# Altium schematic sheet 4_NRF9160.SchDoc(4_NRF9160)
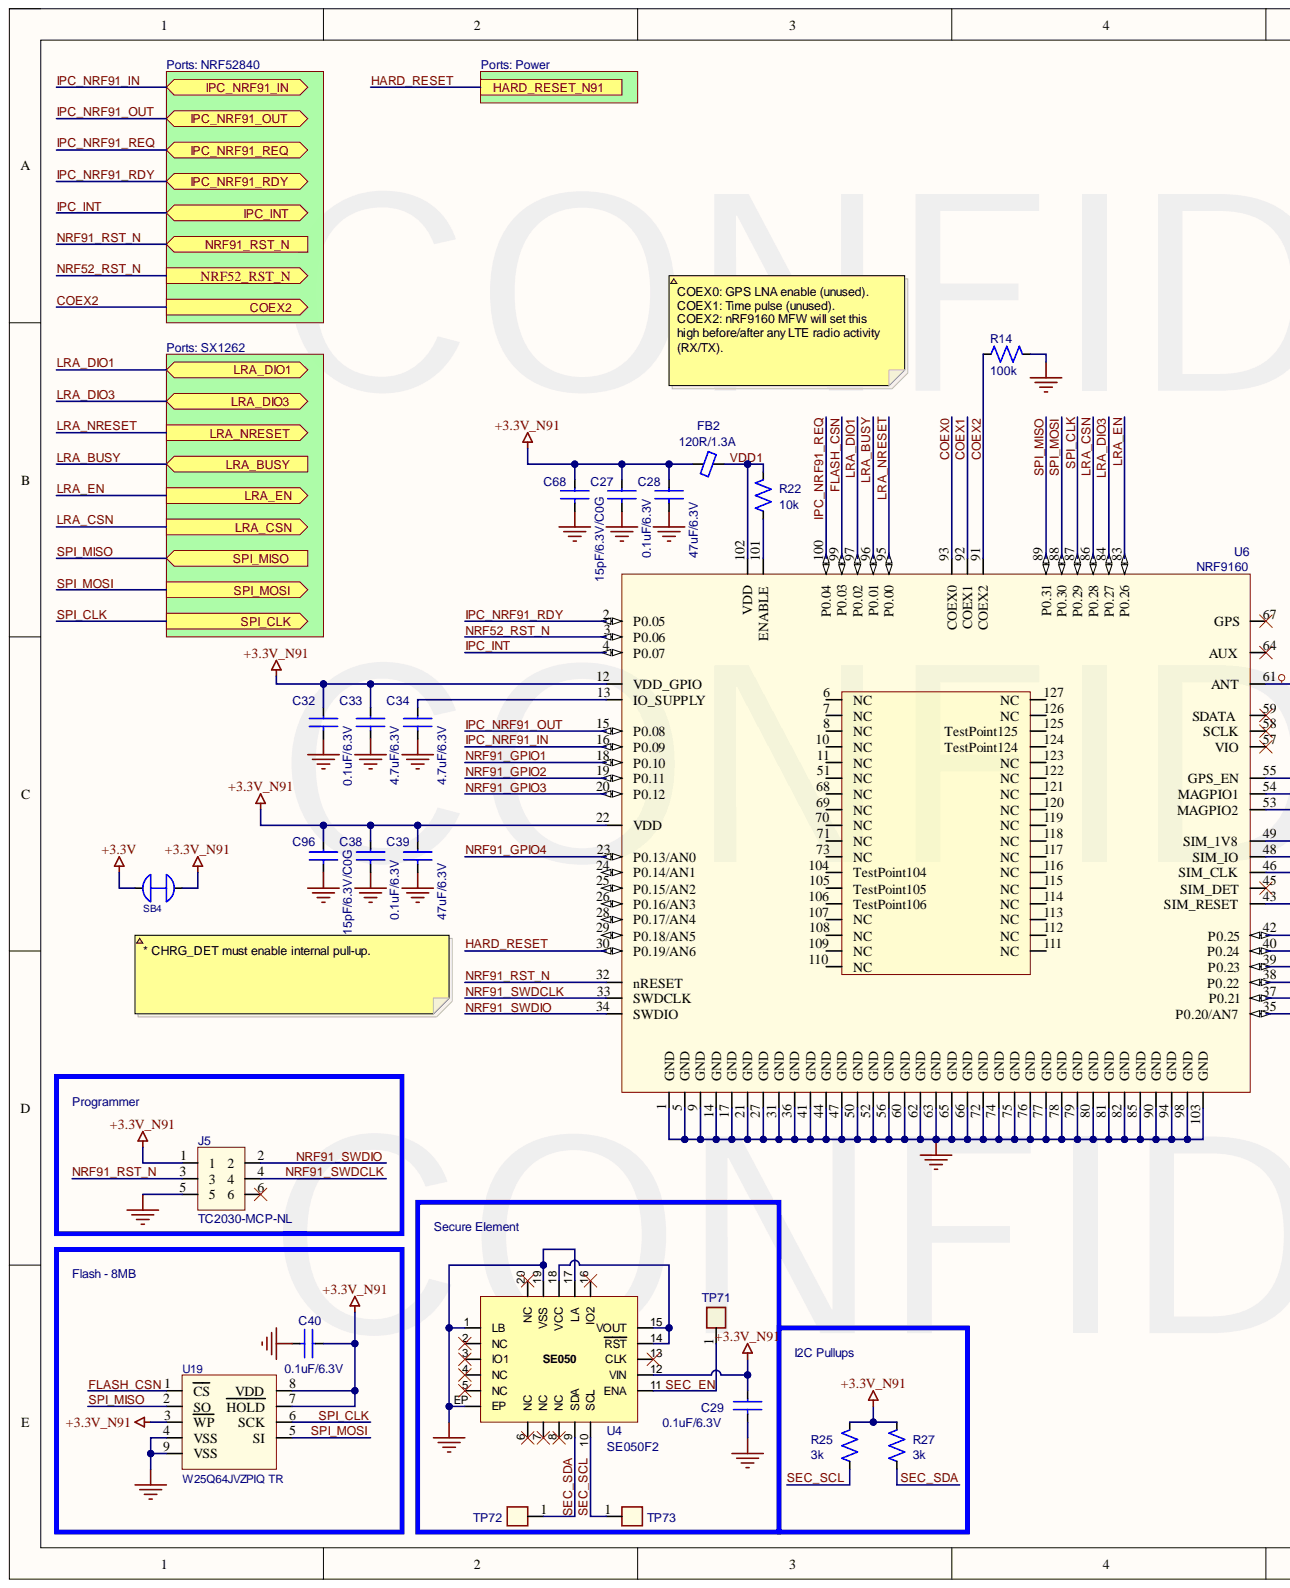
[diagram p0: 4_NRF9160.SchDoc, left]
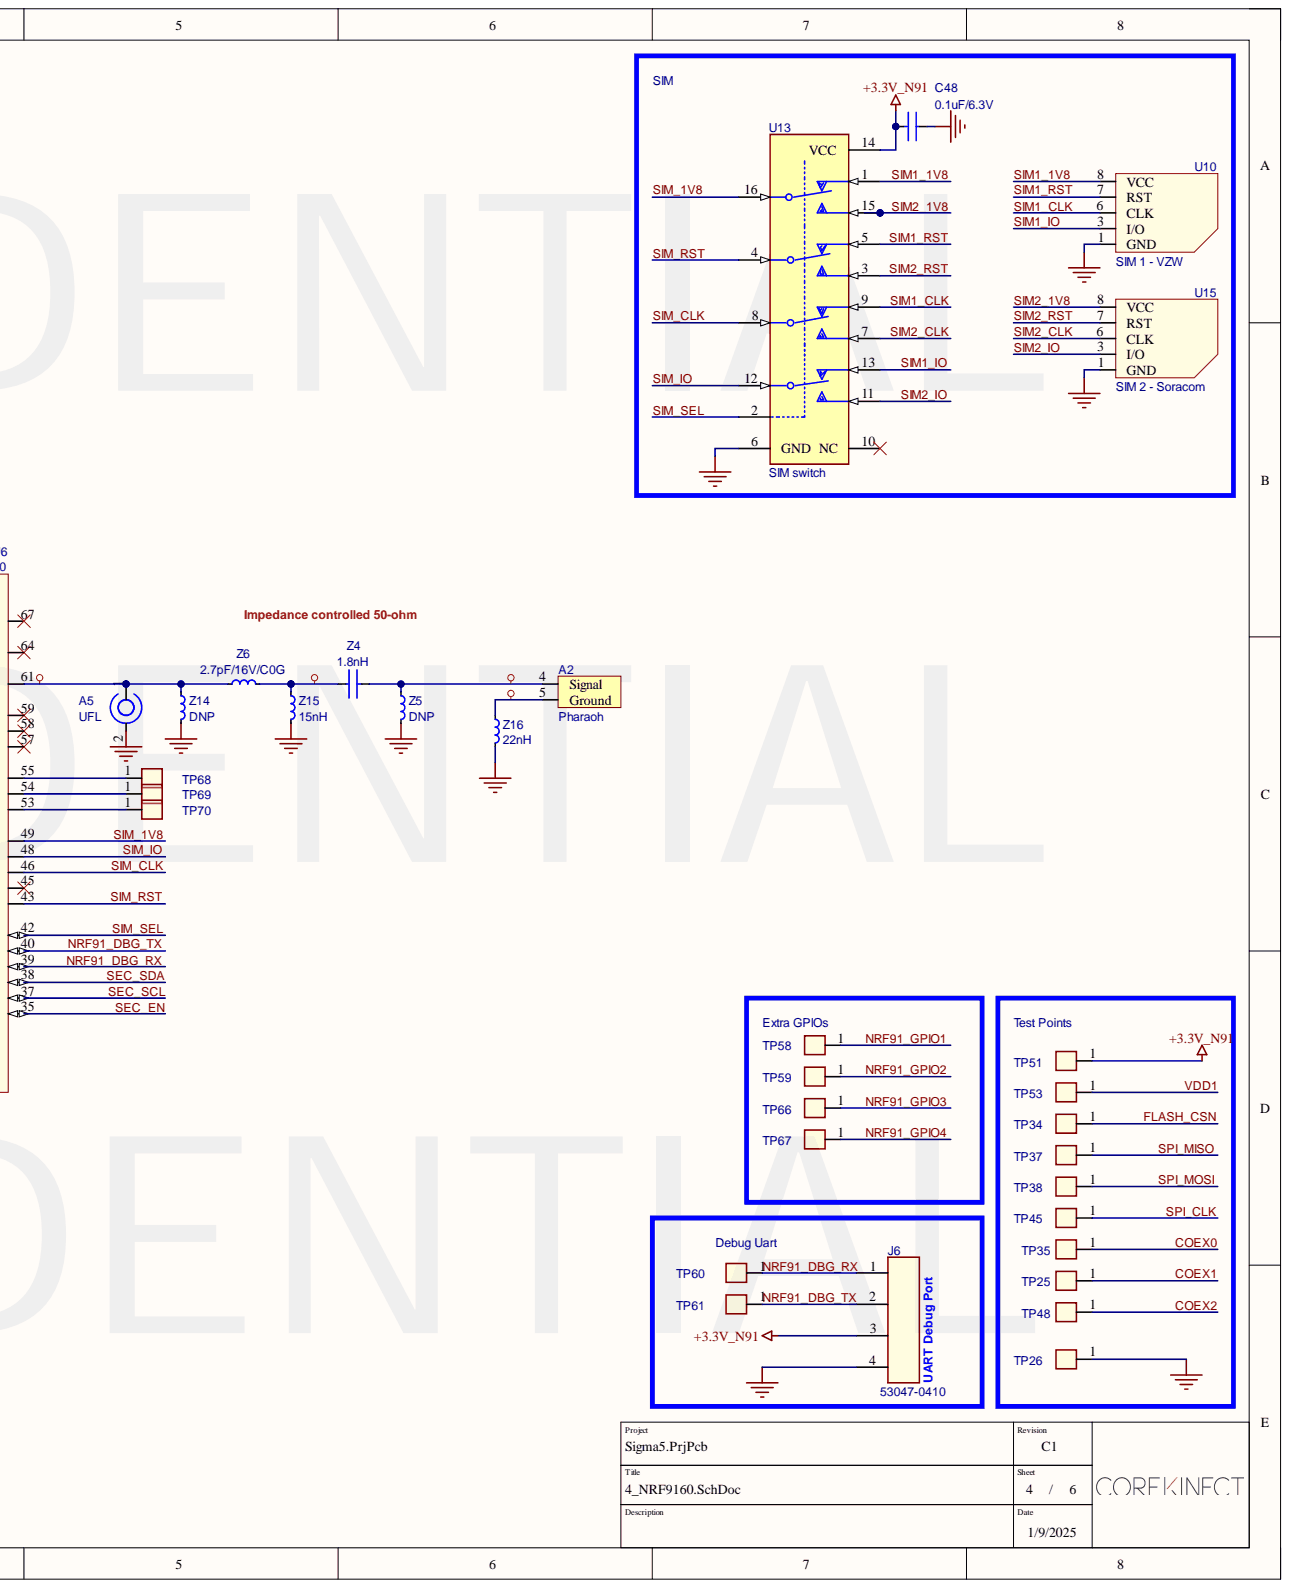
[diagram p1: 4_NRF9160.SchDoc, right]

## Parts
A2  Pharaoh  [Pharaoh_Ant]
A5  UFL  [U.FL-INLINE]
C27  0.1uF/6.3V  [CAP_0402]
C28  47uF/6.3V  [SMT_0805]
C29  0.1uF/6.3V  [CAP_0402]
C32  0.1uF/6.3V  [CAP_0402]
C33  4.7uF/6.3V  [CAP_0603]
C34  4.7uF/6.3V  [CAP_0603]
C38  0.1uF/6.3V  [CAP_0402]
C39  47uF/6.3V  [SMT_0805]
C40  0.1uF/6.3V  [CAP_0402]
C48  0.1uF/6.3V  [CAP_0402]
C68  15pF/6.3V/C0G  [CAP_0402]
C96  15pF/6.3V/C0G  [CAP_0402]
FB2  120R/1.3A  [CAP_0402]
J5  TC2030-MCP-NL  [TC2030-MCP-NL]
J6  53047-0410  [0530470410_Molex]
R14  100k  [RES_0402]
R22  10k  [RES_0402]
R25  3k  [RES_0402]
R27  3k  [RES_0402]
SB4  SOLDER BRIDGE CLOSED  [RES_0402]  (value not drawn)
TP25  TP  [SMT_TP35_SM]
TP26  TP  [SMT_TP35_SM]
TP34  TP  [SMT_TP35_SM]
TP35  TP  [SMT_TP35_SM]
TP37  TP  [SMT_TP35_SM]
TP38  TP  [SMT_TP35_SM]
TP45  TP  [SMT_TP35_SM]
TP48  TP  [SMT_TP35_SM]
TP51  TP  [SMT_TP35_SM]
TP53  TP  [SMT_TP35_SM]
TP58  TP  [SMT_TP35_SM]
TP59  TP  [SMT_TP35_SM]
TP60  TP  [SMT_TP35_SM]
TP61  TP  [SMT_TP35_SM]
TP66  TP  [SMT_TP35_SM]
TP67  TP  [SMT_TP35_SM]
TP68  TP  [SMT_TP35_SM]
TP69  TP  [SMT_TP35_SM]
TP70  TP  [SMT_TP35_SM]
TP71  TP  [SMT_TP35_SM]
TP72  TP  [SMT_TP35_SM]
TP73  TP  [SMT_TP35_SM]
U4  SE050F2  [NXP_SE050]
U6  NRF9160  [nRF9160_DEV2_MODULE]
U10  SIM 1 - VZW  [SIM-ST-MFF2]
U13  SIM switch  [FP-523BF-MFG]
U15  SIM 2 - Soracom  Manufacturer 1: Soracom  [SIM-ST-MFF2]
U19  W25Q64JVZPIQ TR  [WSON8-6x5mm]
Z4  1.8nH  [CAP_0402]
Z5  DNP  [CAP_0402]
Z6  2.7pF/16V/C0G  [CAP_0402]
Z14  DNP  [CAP_0402]
Z15  15nH  [CAP_0402]
Z16  22nH  [CAP_0402]

## Ports
COEX2, HARD_RESET_N91, IPC_INT, IPC_NRF91_IN, IPC_NRF91_OUT, IPC_NRF91_RDY, IPC_NRF91_REQ, LRA_BUSY, LRA_CSN, LRA_DIO1, LRA_DIO3, LRA_EN, LRA_NRESET, NRF52_RST_N, NRF91_RST_N, SPI_CLK, SPI_MISO, SPI_MOSI

## Nets
+3.3V: SB4.1
+3.3V_N91: C27.1 C28.1 C29.1 C32.1 C33.1 C38.1 C39.1 C40.1 C48.2 C68.1 C96.1 FB2.1 J5.1 J6.3 R25.2 R27.2 SB4.2 TP51.1 U4.12(VIN) U6.12(VDD_GPIO) U6.22(VDD) U13.14(VCC) U19.3(WP) U19.7(HOLD) U19.8(VDD)
GND: A5.2 C27.2 C28.2 C29.2 C32.2 C33.2 C34.2 C38.2 C39.2 C40.2 C48.1 C68.2 C96.2 J5.5 J6.4 R14.2 TP26.1 U4.1(LB) U4.17(LA) U4.19(VSS) U4.EP U6.1(GND) U6.5(GND) U6.9(GND) U6.14(GND) U6.17(GND) U6.21(GND) U6.27(GND) U6.31(GND) U6.36(GND) U6.41(GND) U6.44(GND) U6.47(GND) U6.50(GND) U6.52(GND) U6.56(GND) U6.60(GND) U6.62(GND) U6.63(GND) U6.65(GND) U6.66(GND) U6.72(GND) U6.74(GND) U6.75(GND) U6.76(GND) U6.77(GND) U6.78(GND) U6.79(GND) U6.80(GND) U6.81(GND) U6.82(GND) U6.85(GND) U6.90(GND) U6.94(GND) U6.98(GND) U6.103(GND) U10.1(GND) U13.6(GND) U15.1(GND) U19.4(VSS) U19.9(VSS) Z5.2 Z14.2 Z15.2 Z16.2
COEX0: TP35.1 U6.93(COEX0)
COEX1: TP25.1 U6.92(COEX1)
COEX2: R14.1 TP48.1 U6.91(COEX2) ; port COEX2
FLASH_CSN: TP34.1 U6.99(P0.03) U19.1(CS)
HARD_RESET: U6.30(P0.19/AN6) ; port HARD_RESET_N91
IPC_INT: U6.4(P0.07) ; port IPC_INT
IPC_NRF91_IN: U6.16(P0.09) ; port IPC_NRF91_IN
IPC_NRF91_OUT: U6.15(P0.08) ; port IPC_NRF91_OUT
IPC_NRF91_RDY: U6.2(P0.05) ; port IPC_NRF91_RDY
IPC_NRF91_REQ: U6.100(P0.04) ; port IPC_NRF91_REQ
LRA_BUSY: U6.96(P0.01) ; port LRA_BUSY
LRA_CSN: U6.86(P0.28) ; port LRA_CSN
LRA_DIO1: U6.97(P0.02) ; port LRA_DIO1
LRA_DIO3: U6.84(P0.27) ; port LRA_DIO3
LRA_EN: U6.83(P0.26) ; port LRA_EN
LRA_NRESET: U6.95(P0.00) ; port LRA_NRESET
NRF52_RST_N: U6.3(P0.06) ; port NRF52_RST_N
NRF91_DBG_RX: J6.1 TP60.1 U6.39(P0.23)
NRF91_DBG_TX: J6.2 TP61.1 U6.40(P0.24)
NRF91_GPIO1: TP58.1 U6.18(P0.10)
NRF91_GPIO2: TP59.1 U6.19(P0.11)
NRF91_GPIO3: TP66.1 U6.20(P0.12)
NRF91_GPIO4: TP67.1 U6.23(P0.13/AN0)
NRF91_RST_N: J5.3 U6.32(nRESET) ; port NRF91_RST_N
NRF91_SWDCLK: J5.4 U6.33(SWDCLK)
NRF91_SWDIO: J5.2 U6.34(SWDIO)
SEC_EN: TP71.1 U4.11(ENA) U6.35(P0.20/AN7)
SEC_SCL: R25.1 TP73.1 U4.10(SCL) U6.37(P0.21)
SEC_SDA: R27.1 TP72.1 U4.9(SDA) U6.38(P0.22)
SIM1_1V8: U10.8(VCC) U13.1
SIM1_CLK: U10.6(CLK) U13.9
SIM1_IO: U10.3(I/O) U13.13
SIM1_RST: U10.7(RST) U13.5
SIM2_1V8: U13.15 U15.8(VCC)
SIM2_CLK: U13.7 U15.6(CLK)
SIM2_IO: U13.11 U15.3(I/O)
SIM2_RST: U13.3 U15.7(RST)
SIM_1V8: U6.49(SIM_1V8) U13.16
SIM_CLK: U6.46(SIM_CLK) U13.8
SIM_IO: U6.48(SIM_IO) U13.12
SIM_RST: U6.43(SIM_RESET) U13.4
SIM_SEL: U6.42(P0.25) U13.2
SPI_CLK: TP45.1 U6.87(P0.29) U19.6(SCK) ; port SPI_CLK
SPI_MISO: TP37.1 U6.89(P0.31) U19.2(SO) ; port SPI_MISO
SPI_MOSI: TP38.1 U6.88(P0.30) U19.5(SI) ; port SPI_MOSI
VDD1: FB2.2 R22.2 TP53.1 U6.102(VDD)
NetA2_4: A2.4 Z4.2 Z5.1
NetA2_5: A2.5 Z16.1
NetA5_1: A5.1 U6.61(ANT) Z6.1 Z14.1
NetC34_1: C34.1 U6.13(IO_SUPPLY)
NetR22_1: R22.1 U6.101(ENABLE)
NetTP68_1: TP68.1 U6.55(GPS_EN)
NetTP69_1: TP69.1 U6.54(MAGPIO1)
NetTP70_1: TP70.1 U6.53(MAGPIO2)
NetU4_14: U4.14(RST) U4.15(VOUT) U4.18(VCC)
NetZ4_1: Z4.1 Z6.2 Z15.1

Not connected: J5 6; U4 2(NC) 3(IO1) 4(NC) 5(NC) 6(NC) 7(NC) 8(NC) 13(CLK) 16(IO2) 20(NC); U6 6(NC) 7(NC) 8(NC) 10(NC) 11(NC) 24(P0.14/AN1) 25(P0.15/AN2) 26(P0.16/AN3) 28(P0.17/AN4) 29(P0.18/AN5) 45(SIM_DET) 51(NC) 57(VIO) 58(SCLK) 59(SDATA) 64(AUX) 67(GPS) 68(NC) 69(NC) 70(NC) 71(NC) 73(NC) 104(TestPoint104) 105(TestPoint105) 106(TestPoint106) 107(NC) 108(NC) 109(NC) 110(NC) 111(NC) 112(NC) 113(NC) 114(NC) 115(NC) 116(NC) 117(NC) 118(NC) 119(NC) 120(NC) 121(NC) 122(NC) 123(NC) 124(TestPoint124) 125(TestPoint125) 126(NC) 127(NC); U13 10(NC)

## Library data of the parts
A2 = SR4L073: Antenna Cellular -6dBi Gain 960MHz/2200MHz/2400MHz/2690MHz 18-Pin SMD; Manufacturer: Antenova; Notes: LTE antenna; Component Kind: Standard; Manufacturer Part Number: SR4L073; Pricing 1: 1=5.19, 10=4.663, 25=4.4088, 100=3.8208, 250=3.62488 (USD); RoHS: No; Stock 1: 502; Supplier 1: Digi-Key; Supplier Part Number 1: 627-SR4L073DKR-ND; Library Name: CoreKinectIntegratedLibrary.IntLib
A5 = UFL_INLINE: Component Kind: Standard; Library Name: CoreKinectIntegratedLibrary.IntLib
C27 C29 C32 C38 C40 C48 C68 C96 = CAP_0402: Component Kind: Standard; Package / Case: 0402; Library Name: CoreKinectIntegratedLibrary.IntLib
C28 C39 = CAP_0805: Component Kind: Standard; Package / Case: 0805; Library Name: CoreKinectIntegratedLibrary.IntLib
C33 C34 = CAP_0603: Component Kind: Standard; Package / Case: 0603; Library Name: CoreKinectIntegratedLibrary.IntLib
FB2 = BLM15PD121SN1D: Ferrite Bead, 120 ohm @ 100MHz, 1.3 A, BLM15PD Series, 0.09 ohm, ± 25%; Manufacturer 1: Murata; Current Rating: 1.3A; DC Resistance (DCR): 90mR; Impedance: 120R; Material: Steel,Ferrite; Number of Circuits: 1; Case Code (Imperial): 0402; Case Code (Metric): 1005; Case/Package: 0402; Category: Inductors; Component Kind: Standard; ComponentLink1Description: https://datasheet.ciiva.com/18093/getdatasheetpartid-195858-18093157.pdf?src-supplier=Verical; ComponentLink1URL: https://datasheet.ciiva.com/18093/getdatasheetpartid-195858-18093157.pdf?src-supplier=Verical; ComponentLink2Description: https://datasheet.ciiva.com/11800/0900766b811b5866-11800860.pdf?src-supplier=RSComponents; ComponentLink2URL: https://datasheet.ciiva.com/11800/0900766b811b5866-11800860.pdf?src-supplier=RSComponents; ComponentLink3Description: https://datasheet.ciiva.com/41425/001171686-da-01-en-chip-drossel0402-120r-1300ma-1-0x0-5mm-41425776.pdf?src-supplier=Conrad; ComponentLink3URL: https://datasheet.ciiva.com/41425/001171686-da-01-en-chip-drossel0402-120r-1300ma-1-0x0-5mm-41425776.pdf?src-supplier=Conrad; ComponentLink4Description: https://datasheet.ciiva.com/41449/001172205-da-01-en-drossel-0402-120r-11-0x0-5mm-10000st-41449495.pdf?src-supplier=Conrad; ComponentLink4URL: https://datasheet.ciiva.com/41449/001172205-da-01-en-drossel-0402-120r-11-0x0-5mm-10000st-41449495.pdf?src-supplier=Conrad; ComponentLink5Description: https://datasheet.ciiva.com/1012/l0110e-1012436.pdf?src-supplier=Digi-Key; ComponentLink5URL: https://datasheet.ciiva.com/1012/l0110e-1012436.pdf?src-supplier=Digi-Key; ComponentLink6Description: https://datasheet.ciiva.com/1012/l0110e-1012434.pdf?src-supplier=Digi-Key; ComponentLink6URL: https://datasheet.ciiva.com/1012/l0110e-1012434.pdf?src-supplier=Digi-Key; ComponentLink7Description: https://datasheet.ciiva.com/1012/l0110e-1012435.pdf?src-supplier=Digi-Key; ComponentLink7URL: https://datasheet.ciiva.com/1012/l0110e-1012435.pdf?src-supplier=Digi-Key; Core Material: Ferrite; DC Current: 1.3A; DC Resistance-Max: 90mR; Depth: 0.55mm; Height: 0.5mm; Lead Free: Lead Free; Length: 1mm; Manufacturer Part Number 1: BLM15PD121SN1D; Max Current Rating: 1.3A; Max DC Current: 1.3A; Max Operating Temperature: 125°C; Military Standard: Not; Min Operating Temperature: -55°C; Number of Lines: 1; Number of Pins: 2; Package Quantity: 1; Packaging: Tape and Reel; Pricing 1: 10000=0.01914, 20000=0.01848, 30000=0.01716, 50000=0.01696 (USD); Radiation Hardening: No; REACH SVHC: No SVHC; RoHS: Yes; RoHS Compliant: Yes; Series: BLM15PD; Stock 1: 240000; Supplier 1: Digi-Key; Supplier Part Number 1: 490-5203-2-ND; Termination: SMD/SMT; Test Frequency: 100MHz; Tolerance: 25%; Width: 0.5mm; Library Name: CoreKinectIntegratedLibrary.IntLib
J5 = TC2030-MCP-NL: CABLE TAG-CONNECT IN-CIRCUIT; Component Kind: Standard; PN: TC2030-MCP-NL; Library Name: Project Zenith.SchLib
J6 = MOLEX_53047-0410: GLOVE, POLYAMIDE, L/FREE, PU, SIZE 6 - More Details; Manufacturer 1: Molex; Contact Resistance: 20mR; Current: 1A; Gender: Male; Glow Wire Compliant: Non-compliant; Insulation Resistance: 100MR; Max Voltage Rating (AC): 125V; Number of Circuits: 4; Pitch: 1.25mm; Plating: Tin; Case/Package: 0410; Category: Headers and Wire Housings; Color: Natural; Component Kind: Standard; ComponentLink1Description: https://datasheet.ciiva.com/7844/1838335-7844032.pdf?src-supplier=Element14; ComponentLink1URL: https://datasheet.ciiva.com/7844/1838335-7844032.pdf?src-supplier=Element14; ComponentLink2Description: https://datasheet.ciiva.com/30144/347020530470410-pcb-headers-30144214.pdf?src-supplier=Onlinecomponents.com; ComponentLink2URL: https://datasheet.ciiva.com/30144/347020530470410-pcb-headers-30144214.pdf?src-supplier=Onlinecomponents.com; ComponentLink3Description: https://datasheet.ciiva.com/20207/22-3930e-20207527.pdf?src-supplier=Rapid+Electronics; ComponentLink3URL: https://datasheet.ciiva.com/20207/22-3930e-20207527.pdf?src-supplier=Rapid+Electronics; ComponentLink4Description: https://datasheet.ciiva.com/5149/0530470410-pcb-headers-170953-5149004.pdf?src-supplier=Mouser; ComponentLink4URL: https://datasheet.ciiva.com/5149/0530470410-pcb-headers-170953-5149004.pdf?src-supplier=Mouser; ComponentLink5Description: https://datasheet.ciiva.com/11746/0900766b8024e2fd-11746351.pdf?src-supplier=RSComponents; ComponentLink5URL: https://datasheet.ciiva.com/11746/0900766b8024e2fd-11746351.pdf?src-supplier=RSComponents; ComponentLink6Description: https://datasheet.ciiva.com/16658/0530470410-pcb-headers-16658419.pdf?src-supplier=Avnet+Europe; ComponentLink6URL: https://datasheet.ciiva.com/16658/0530470410-pcb-headers-16658419.pdf?src-supplier=Avnet+Europe; ComponentLink7Description: https://datasheet.ciiva.com/27054/1932483-27054996.pdf?src-supplier=Jameco; ComponentLink7URL: https://datasheet.ciiva.com/27054/1932483-27054996.pdf?src-supplier=Jameco; ComponentLink8Description: https://datasheet.ciiva.com/14156/ge116819-116832-e-14156235.pdf?src-supplier=Distrelec; ComponentLink8URL: https://datasheet.ciiva.com/14156/ge116819-116832-e-14156235.pdf?src-supplier=Distrelec; ComponentLink9Description: https://datasheet.ciiva.com/10088/0530470410-pcb-headers-10088984.pdf?src-supplier=Avnet; ComponentLink9URL: https://datasheet.ciiva.com/10088/0530470410-pcb-headers-10088984.pdf?src-supplier=Avnet; Contact Material: Bronze,Tin; Contact Plating: Tin; Current Rating: 1A; Flammability Rating: UL94 V-0; Housing Color: Natural; Housing Material: Polyamide; Lead Free: Lead Free; Manufacturer Part Number 1: 53047-0410; Max Operating Temperature: 85°C; Min Operating Temperature: -40°C; Mount: Through Hole; Number of Contacts: 4; Number of Positions: 4; Number of Rows: 1; Orientation: Vertical; Package Quantity: 5000; Packaging: Tray; Pricing 1: 1=0.28000, 10=0.26100, 100=0.18300, 500=0.15688, 1000=0.13073, 5000=0.11205, 10000=0.10458 (USD); Radiation Hardening: No; REACH SVHC: Unknown; RoHS: Yes; Stock 1: 47330; Supplier 1: Digi-Key; Supplier Part Number 1: WM1733-ND; Termination: Solder; Voltage: 125V; Voltage Rating: 125V; Voltage Rating (AC): 125V; Voltage Rating (DC): 125V; Working Voltage: 125V; Library Name: CoreKinectIntegratedLibrary.IntLib
R14 R22 R25 R27 = RES_0402: Component Kind: Standard; Package / Case: 0402; Library Name: CoreKinectIntegratedLibrary.IntLib
SB4 = MISC-00000B: Short circuit 0402; Manufacturer 1: CoreKinect; Manufacturer Part Number 1: Short-Circuit; Database Table Name: Misc; Component Kind: Net Tie; CPN: MISC-00000B; Library Name: CoreKinectDatabaseLibrary.DbLib
TP25 TP26 TP34 TP35 TP37 TP38 TP45 TP48 TP51 TP53 TP58-TP61 TP66-TP73 = CON1_1: PN: SMT_TP35; Component Kind: Standard
U4 = SE050F2: NXP EdgeLock Secure Element; Manufacturer 1: NXP Semiconductors; Manufacturer Part Number 1: SE050F2HQ1/Z018HZ; Category: Uncategorized; Component Kind: Standard; Pricing 1: 3000=2.13928, 6000=2.09440, 12000=2.03456, 18000=2.00464, 24000=1.95976, 30000=1.91488, 300000=1.85504 (USD); RoHS: No; Supplier 1: Avnet; Supplier Part Number 1: SE050F2HQ1/Z018HZ; Library Name: CoreKinectIntegratedLibrary.IntLib
U6 = NRF9160-SICA-R7: Rf Transceiver, 2.2Ghz, -40 To 85Deg C Rohs Compliant: Yes; Manufacturer 1: Nordic Semiconductor; Manufacturer Part Number 1: NRF9160-SICA-B1A-R; Component Kind: Standard; PN: NRF9160-SICA-R7; Pricing 1: 100=18.71390, 300=17.85203, 500=16.99022, 1000=16.00528, 2500=15.97200 (USD); RoHS: No; Stock 1: 4500; Supplier 1: Digi-Key; Supplier Part Number 1: 1490-1076-2-ND; Library Name: CoreKinectIntegratedLibrary.IntLib
U10 = SIM-ST-MFF2: VERIZON IOT EMBEDDED SIM CHIP; Manufacturer 1: STMicroelectronics; Manufacturer Part Number 1: OIMMA8MBHP01701$; Component Kind: Standard; Library Name: PERS-REVA0.SCHLIB
U13 = FSA2567UMX: FSA Series 4PDT 4.3 V 6 Ohm SMT Low Power Dual SIM Card Analog Switch - UMLP-16; Manufacturer: ON Semiconductor / Fairchild; -3db Bandwidth: 475MHz; Max Supply Current: 15uA; Max Supply Voltage: 4.3V; Min Supply Voltage: 2.7V; Number of Channels: 1; Number of Inputs: 4; Number of Outputs: 8; Supply Current: 1uA; Supply Type: Single; Category: Interface ICs; Component Kind: Standard; ComponentLink1Description: https://datasheet.ciiva.com/17282/fsa2567-17282640.pdf?src-supplier=Future+Electronics; ComponentLink1URL: https://datasheet.ciiva.com/17282/fsa2567-17282640.pdf?src-supplier=Future+Electronics; ComponentLink2Description: https://datasheet.ciiva.com/12191/fsa2567-12191190.pdf?src-supplier=Mouser; ComponentLink2URL: https://datasheet.ciiva.com/12191/fsa2567-12191190.pdf?src-supplier=Mouser; ComponentLink3Description: https://datasheet.ciiva.com/2979/fsa2567-2979468.pdf?src-supplier=Avnet; ComponentLink3URL: https://datasheet.ciiva.com/2979/fsa2567-2979468.pdf?src-supplier=Avnet; ComponentLink4Description: https://datasheet.ciiva.com/6637/fsa2567-6637130.pdf?src-supplier=Digi-Key; ComponentLink4URL: https://datasheet.ciiva.com/6637/fsa2567-6637130.pdf?src-supplier=Digi-Key; ComponentLink5Description: https://datasheet.ciiva.com/6637/fsa2567-6637133.pdf?src-supplier=Digi-Key; ComponentLink5URL: https://datasheet.ciiva.com/6637/fsa2567-6637133.pdf?src-supplier=Digi-Key; Height: 0.5mm; Lead Free: Lead Free; Length: 1.8mm; Manufacturer Part Number: FSA2567UMX; Max Operating Temperature: 85°C; Min Operating Temperature: -40°C; Mount: Surface Mount; Number of Pins: 16; Package Quantity: 5000; Packaging: Tape and Reel; Pricing 1: 1=1.04, 10=0.926, 25=0.8792, 100=0.6751, 250=0.5966, 500=0.56522, 1000=0.43961, 2500=0.41763 (USD); Radiation Hardening: No; Reach SVHC Compliant: No; RoHS: Yes; RoHS Compliant: Yes; Series: -; Stock 1: 79567; Supplier 1: Digi-Key; Supplier Part Number 1: FSA2567UMXDKR-ND; Throw Configuration: 4PDT; Weight: 0.00654g; Width: 2.6mm; Library Name: CoreKinectIntegratedLibrary.IntLib
U15 = SIM-ST-MFF2: SORACOM IOT EMBEDDED SIM CHIP; Manufacturer Part Number 1: SGEIL01; Component Kind: Standard; Library Name: PERS-REVA0.SCHLIB
U19 = STRG-00000B: FLASH - NOR Memory IC 64Mbit SPI; Manufacturer 1: Winbond; Database Table Name: Storage; Component Kind: Standard; CPN: STRG-00000B; Manufacturer Part Number 1: W25Q64JVZPIQ TR; Library Name: CoreKinectDatabaseLibrary.DbLib
Z4 = CAP_0402: Notes: LTE tuning - High Q; Component Kind: Standard; Package / Case: 0402; Library Name: CoreKinectIntegratedLibrary.IntLib
Z5 Z6 Z14-Z16 = IND_0402: Notes: LTE tuning - High Q; Component Kind: Standard; Package / Case: 0402; Library Name: CoreKinectIntegratedLibrary.IntLib
